# Altium schematic sheet I2C_RTC_DS3231.SchDoc
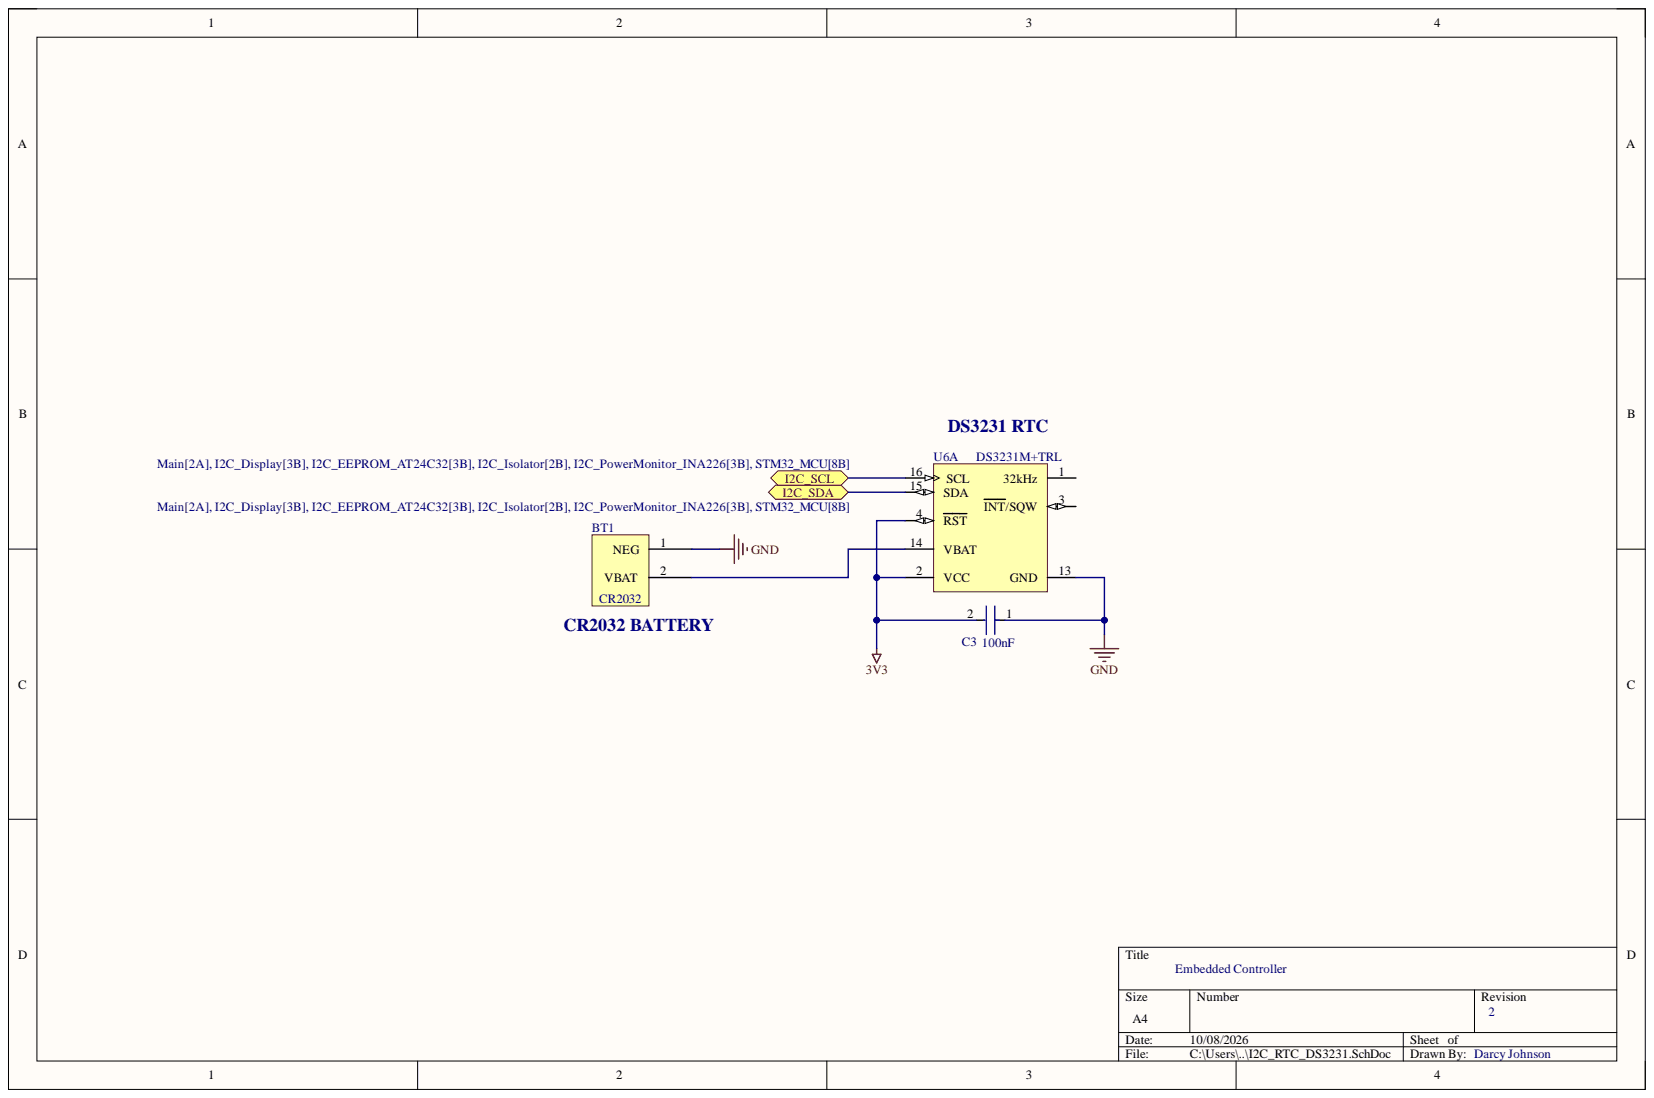
[diagram p0: I2C_RTC_DS3231.SchDoc]

## Parts
BT1  CR2032_HOLDER_TH  [CR2032_HOLDER_TH]  (value not drawn)
C3  100nF  [FP-CGA3E-0_3-0_1-IPC_A]
U6  DS3231M+TRL  [W16MK+1_N]  (part A)

## Ports
I2C_SCL, I2C_SDA

## Nets
3V3: C3.2 U6.2(VCC) U6.4(RST)
GND: BT1.1 C3.1 U6.13(GND)
I2C_SCL: U6.16(SCL) ; port I2C_SCL
I2C_SDA: U6.15(SDA) ; port I2C_SDA
NetBT1_2: BT1.2 U6.14(VBAT)
NetU6_1: U6.1(32kHz)
NetU6_3: U6.3(INT/SQW)

## Library data of the parts
C3 = CMP-2000-07546-2: Cap Ceramic 100nF 50V X7R 10% Pad SMD 0603 +125°C Automotive T/R; Capacitance: 100nF; Case Code (Imperial): 0603; Case Code (Metric): 1608; Case/Package: 0603; Component Kind: Standard; Composition: Ceramic; Depth: 0.8mm; Dielectric: X7R; Height: 0.8mm; Lead Free: Lead Free; Length: 1.6mm; Library Name: Altium Content Vault; Max Operating Temperature: 125°C; Min Operating Temperature: -55°C; Mount: Surface Mount; Package Quantity: 1; Packaging: Tape and Reel; Radiation Hardening: No; Ratings: AEC-Q200; REACH SVHC: No SVHC; Termination: SMD/SMT; Thickness: 0.035inch; Tolerance: 10%; Voltage: 50V; Voltage Rating: 50V; Voltage Rating (DC): 50V; Weight: 7.1E-05oz; Width: 0.8mm
U6 = CMP-0254-00002-2: +/-5ppm, MEMS based Real-Time Clock, I2C Interface, 16-Pin SO, -40 to 85 degC, Pb-Free, Reel; Code_IPC: SOIC127P1030-16; Code_JEDEC: MS-013-AA; Component Kind: Standard; ComponentLink1Description: Manufacturer URL; ComponentLink1URL: http://www.maxim-ic.com; ComponentLink2Description: Datasheet; ComponentLink2URL: http://datasheets.maxim-ic.com/en/ds/DS3231M.pdf; ComponentLink3Description: Package Specification; ComponentLink3URL: http://pdfserv.maxim-ic.com/package_dwgs/21-0042.PDF; DatasheetVersion: Rev 3, Dec-2011; Library Name: Altium Content Vault; PackageDescription: 16-Pin Small-Outline IC, Wide (0.3in), 1.27 mm Pitch, SOIC (W), Pb-Free; PackageReference: W16MK+1; PackageVersion: revD, 2010
BT1 = CR2032_HOLDER_TH: Component Kind: Standard; Library Name: CR2032_HOLDER_TH.SchLib
